# Altium schematic sheet 21_ATK_RK3588S_USB.SchDoc
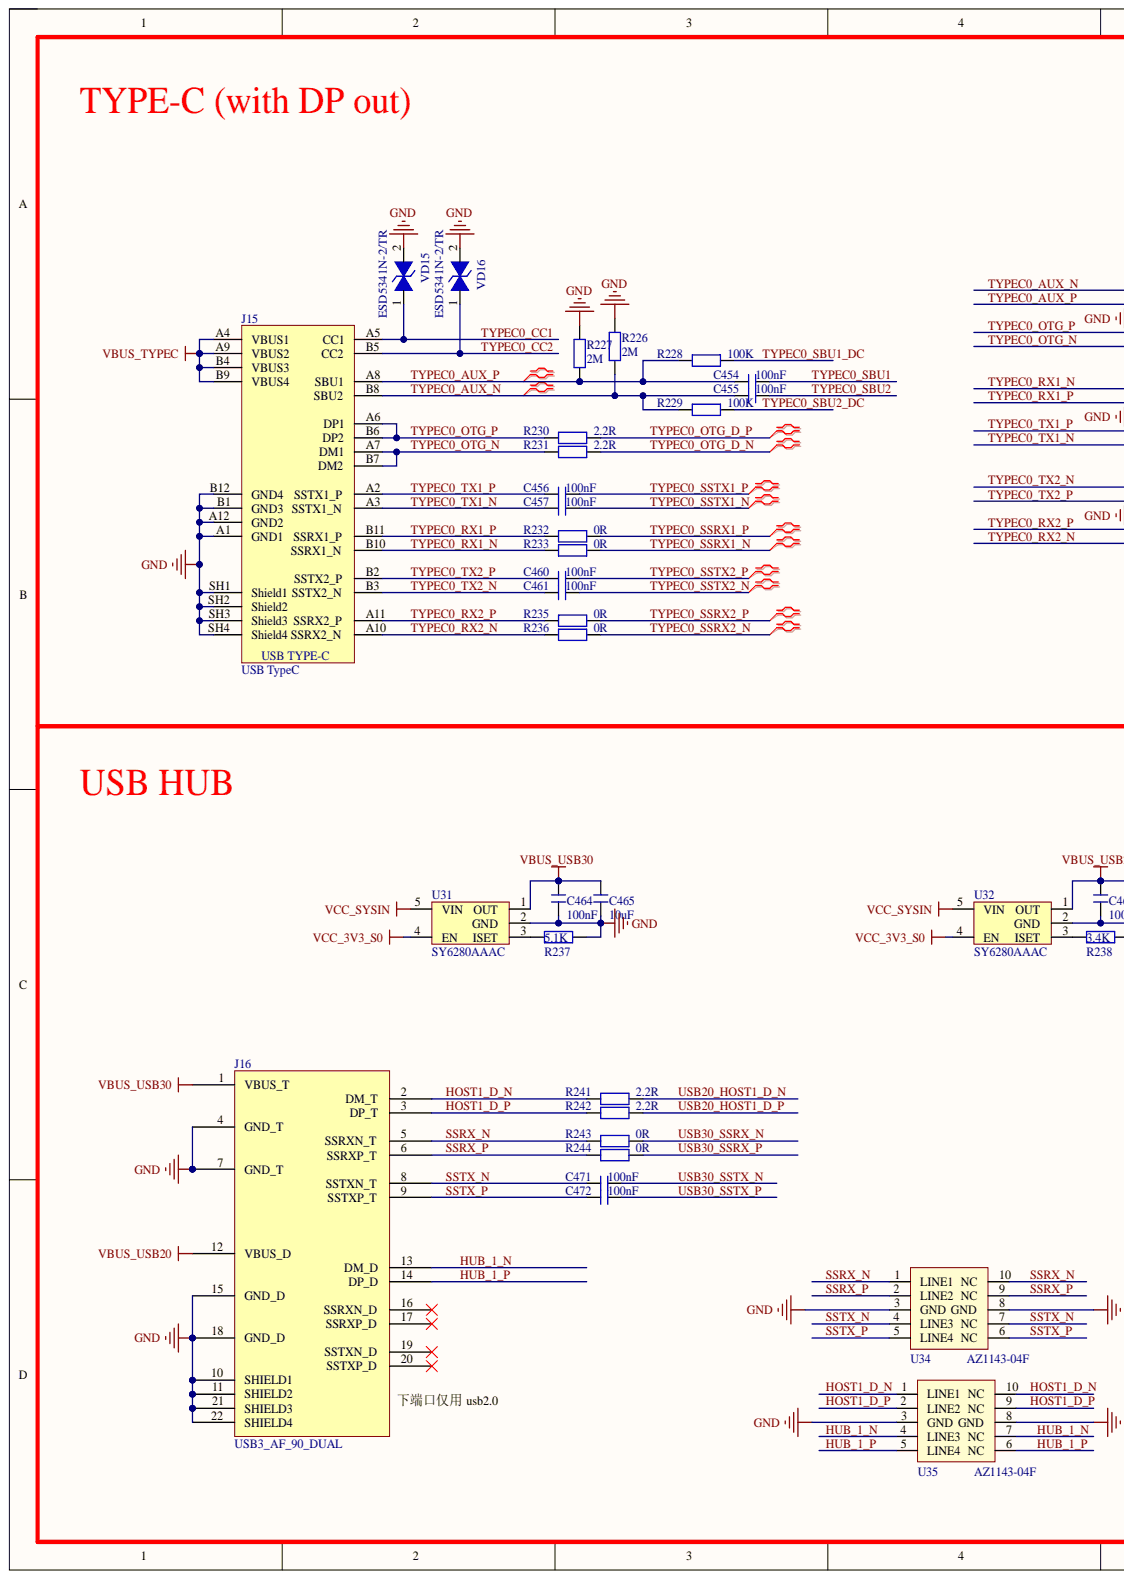
[diagram p0: 21_ATK_RK3588S_USB.SchDoc, left]
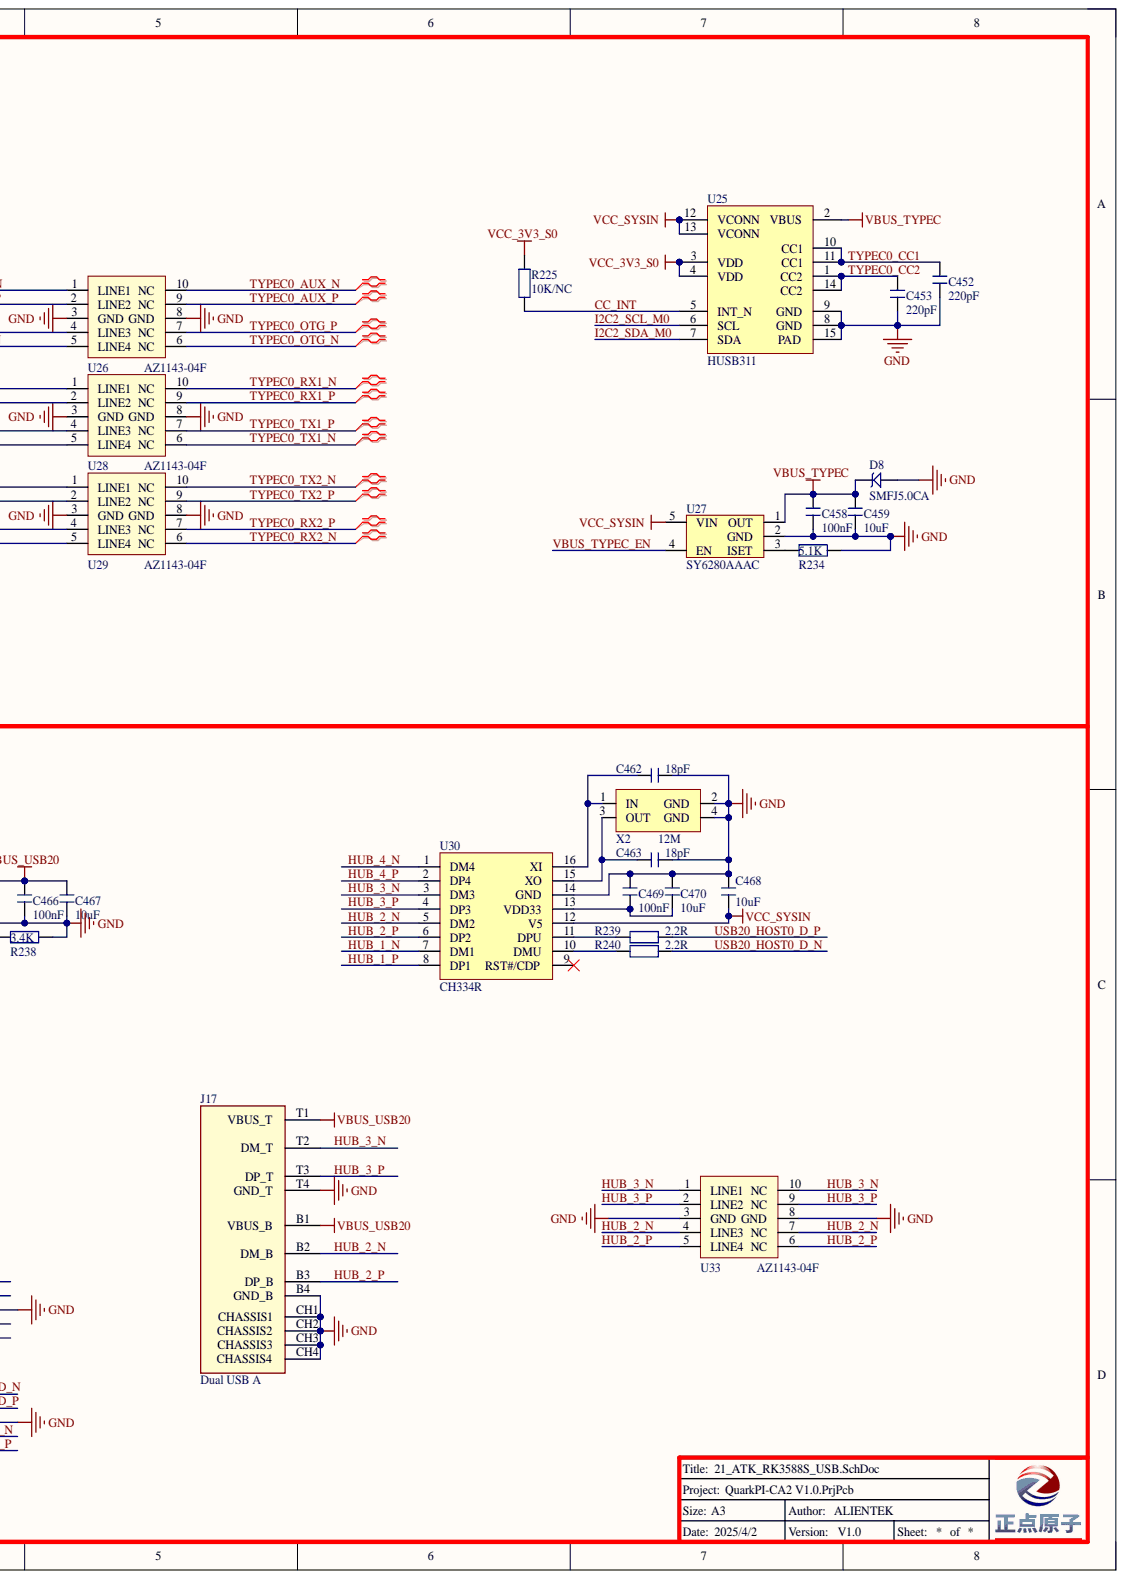
[diagram p1: 21_ATK_RK3588S_USB.SchDoc, right]

## Parts
C452  220pF  [C 0201]
C453  220pF  [C 0201]
C454  100nF  [C 0201]
C455  100nF  [C 0201]
C456  100nF  [C 0201]
C457  100nF  [C 0201]
C458  100nF  [C 0201]
C459  10uF  [C 0402]
C460  100nF  [C 0201]
C461  100nF  [C 0201]
C462  18pF  [C 0402]
C463  18pF  [C 0402]
C464  100nF  [C 0201]
C465  10uF  [C 0402]
C466  100nF  [C 0201]
C467  10uF  [C 0402]
C468  10uF  [C 0402]
C469  100nF  [C 0201]
C470  10uF  [C 0402]
C471  100nF  [C 0201]
C472  100nF  [C 0201]
D8  SMFJ5.0CA  [SOD-123]
J15  USB TypeC  [USB3.2 TYPE-C-24P SMD]
J16  USB3_AF_90_DUAL  [USB3_AF_90_DUAL]
J17  Dual USB A  [USB 2.0 TypeA Dual]
R225  10K/NC  [R 0402]
R226  2M  [R 0402]
R227  2M  [R 0402]
R228  100K  [R 0201]
R229  100K  [R 0201]
R230  2.2R  [R 0201]
R231  2.2R  [R 0201]
R232  0R  [R 0201]
R233  0R  [R 0201]
R234  5.1K  [R 0402]
R235  0R  [R 0201]
R236  0R  [R 0201]
R237  5.1K  [R 0402]
R238  3.4K  [R 0402]
R239  2.2R  [R 0201]
R240  2.2R  [R 0201]
R241  2.2R  [R 0201]
R242  2.2R  [R 0201]
R243  0R  [R 0201]
R244  0R  [R 0201]
U25  HUSB311  [MLP14]
U26  AZ1143-04F  [DFN2510P10E]
U27  SY6280AAAC  [SOT23-5N]
U28  AZ1143-04F  [DFN2510P10E]
U29  AZ1143-04F  [DFN2510P10E]
U30  CH334R  [QSOP16]
U31  SY6280AAAC  [SOT23-5N]
U32  SY6280AAAC  [SOT23-5N]
U33  AZ1143-04F  [DFN2510P10E]
U34  AZ1143-04F  [DFN2510P10E]
U35  AZ1143-04F  [DFN2510P10E]
VD15  ESD5341N-2/TR  [ESD0402]
VD16  ESD5341N-2/TR  [ESD0402]
X2  12M  [XTAL-3225]

## Nets
GND: C452.2 C453.2 C458.2 C459.2 C462.2 C463.2 C464.2 C465.2 C466.2 C467.2 C468.1 C469.1 C470.1 D8.1 J15.A1(GND1) J15.A12(GND2) J15.B1(GND3) J15.B12(GND4) J15.SH1(Shield1) J15.SH2(Shield2) J15.SH3(Shield3) J15.SH4(Shield4) J16.4(GND_T) J16.7(GND_T) J16.10(SHIELD1) J16.11(SHIELD2) J16.15(GND_D) J16.18(GND_D) J16.21(SHIELD3) J16.22(SHIELD4) J17.B4(GND_B) J17.CH1(CHASSIS1) J17.CH2(CHASSIS2) J17.CH3(CHASSIS3) J17.CH4(CHASSIS4) J17.T4(GND_T) R226.2 R227.2 R234.1 R237.1 R238.1 U25.8(GND) U25.9(GND) U25.15(PAD) U26.3(GND) U26.8(GND) U27.2(GND) U28.3(GND) U28.8(GND) U29.3(GND) U29.8(GND) U30.14(GND) U31.2(GND) U32.2(GND) U33.3(GND) U33.8(GND) U34.3(GND) U34.8(GND) U35.3(GND) U35.8(GND) VD15.2 VD16.2 X2.2(GND) X2.4(GND)
VBUS_TYPEC: C458.1 C459.1 D8.2 J15.A4(VBUS1) J15.A9(VBUS2) J15.B4(VBUS3) J15.B9(VBUS4) U25.2(VBUS) U27.1(OUT)
VBUS_USB20: C466.1 C467.1 J16.12(VBUS_D) J17.B1(VBUS_B) J17.T1(VBUS_T) U32.1(OUT)
VBUS_USB30: C464.1 C465.1 J16.1(VBUS_T) U31.1(OUT)
VCC_3V3_S0: R225.1 U25.3(VDD) U25.4(VDD) U31.4(EN) U32.4(EN)
VCC_SYSIN: C468.2 U25.12(VCONN) U25.13(VCONN) U27.5(VIN) U30.12(V5) U31.5(VIN) U32.5(VIN)
CC_INT: R225.2 U25.5(INT_N)
HOST1_D_N: J16.2(DM_T) R241.1 U35.1(LINE1) U35.10(NC)
HOST1_D_P: J16.3(DP_T) R242.1 U35.2(LINE2) U35.9(NC)
HUB_1_N: J16.13(DM_D) U30.7(DM1) U35.4(LINE3) U35.7(NC)
HUB_1_P: J16.14(DP_D) U30.8(DP1) U35.5(LINE4) U35.6(NC)
HUB_2_N: J17.B2(DM_B) U30.5(DM2) U33.4(LINE3) U33.7(NC)
HUB_2_P: J17.B3(DP_B) U30.6(DP2) U33.5(LINE4) U33.6(NC)
HUB_3_N: J17.T2(DM_T) U30.3(DM3) U33.1(LINE1) U33.10(NC)
HUB_3_P: J17.T3(DP_T) U30.4(DP3) U33.2(LINE2) U33.9(NC)
HUB_4_N: U30.1(DM4)
HUB_4_P: U30.2(DP4)
I2C2_SCL_M0: U25.6(SCL)
I2C2_SDA_M0: U25.7(SDA)
SSRX_N: J16.5(SSRXN_T) R243.1 U34.1(LINE1) U34.10(NC)
SSRX_P: J16.6(SSRXP_T) R244.1 U34.2(LINE2) U34.9(NC)
SSTX_N: C471.2 J16.8(SSTXN_T) U34.4(LINE3) U34.7(NC)
SSTX_P: C472.2 J16.9(SSTXP_T) U34.5(LINE4) U34.6(NC)
TYPEC0_AUX_N: C455.2 J15.B8(SBU2) R226.1 R229.1 U26.1(LINE1) U26.10(NC)
TYPEC0_AUX_P: C454.2 J15.A8(SBU1) R227.1 R228.1 U26.2(LINE2) U26.9(NC)
TYPEC0_CC1: C452.1 J15.A5(CC1) U25.10(CC1) U25.11(CC1) VD15.1
TYPEC0_CC2: C453.1 J15.B5(CC2) U25.1(CC2) U25.14(CC2) VD16.1
TYPEC0_OTG_D_N: R231.2
TYPEC0_OTG_D_P: R230.2
TYPEC0_OTG_N: J15.A7(DM1) J15.B7(DM2) R231.1 U26.5(LINE4) U26.6(NC)
TYPEC0_OTG_P: J15.A6(DP1) J15.B6(DP2) R230.1 U26.4(LINE3) U26.7(NC)
TYPEC0_RX1_N: J15.B10(SSRX1_N) R233.1 U28.1(LINE1) U28.10(NC)
TYPEC0_RX1_P: J15.B11(SSRX1_P) R232.1 U28.2(LINE2) U28.9(NC)
TYPEC0_RX2_N: J15.A10(SSRX2_N) R236.1 U29.5(LINE4) U29.6(NC)
TYPEC0_RX2_P: J15.A11(SSRX2_P) R235.1 U29.4(LINE3) U29.7(NC)
TYPEC0_SBU1: C454.1
TYPEC0_SBU1_DC: R228.2
TYPEC0_SBU2: C455.1
TYPEC0_SBU2_DC: R229.2
TYPEC0_SSRX1_N: R233.2
TYPEC0_SSRX1_P: R232.2
TYPEC0_SSRX2_N: R236.2
TYPEC0_SSRX2_P: R235.2
TYPEC0_SSTX1_N: C457.1
TYPEC0_SSTX1_P: C456.1
TYPEC0_SSTX2_N: C461.1
TYPEC0_SSTX2_P: C460.1
TYPEC0_TX1_N: C457.2 J15.A3(SSTX1_N) U28.5(LINE4) U28.6(NC)
TYPEC0_TX1_P: C456.2 J15.A2(SSTX1_P) U28.4(LINE3) U28.7(NC)
TYPEC0_TX2_N: C461.2 J15.B3(SSTX2_N) U29.1(LINE1) U29.10(NC)
TYPEC0_TX2_P: C460.2 J15.B2(SSTX2_P) U29.2(LINE2) U29.9(NC)
USB20_HOST0_D_N: R240.2
USB20_HOST0_D_P: R239.2
USB20_HOST1_D_N: R241.2
USB20_HOST1_D_P: R242.2
USB30_SSRX_N: R243.2
USB30_SSRX_P: R244.2
USB30_SSTX_N: C471.1
USB30_SSTX_P: C472.1
VBUS_TYPEC_EN: U27.4(EN)
NetC462_1: C462.1 U30.16(XI) X2.1(IN)
NetC463_1: C463.1 U30.15(XO) X2.3(OUT)
NetC469_2: C469.2 C470.2 U30.13(VDD33)
NetR234_2: R234.2 U27.3(ISET)
NetR237_2: R237.2 U31.3(ISET)
NetR238_2: R238.2 U32.3(ISET)
NetR239_1: R239.1 U30.11(DPU)
NetR240_1: R240.1 U30.10(DMU)

Not connected: J16 16(SSRXN_D) 17(SSRXP_D) 19(SSTXN_D) 20(SSTXP_D); U30 9(RST#/CDP)

## Library data of the parts
C452-C472 = C: 无极性电容; BOM名称: 无极性贴片电容; 版权归属: 正点原子; Component Kind: Standard; Library Name: 正点原子封装库V1.0.IntLib; 学习资源: www.openedv.com
D8 = SMBJ: TVS; Component Kind: Standard; Library Name: AtomPi-CA1 V1.1.IntLib
J17 = USB 2.0 TypeA Dual: 双层USB2.0 Type A; Parameter: 接口器件; 版权归属: 正点原子; Component Kind: Standard; Library Name: 正点原子封装库V1.0.IntLib; 学习资源: www.openedv.com
R225-R244 = R: 电阻; BOM名称: 贴片电阻; 版权归属: 正点原子; Component Kind: Standard; Library Name: 正点原子封装库V1.0.IntLib; Parameter: 电阻; 学习资源: www.openedv.com
U25 = FUSB302MPX: USB Type-C 控制器; Component Kind: Standard; Library Name: ATK-DLRK3588B V0.2.IntLib
U26 U28 U29 U33-U35 = AZ1143-04F: ESD保护二极管阵列; Component Kind: Standard; Library Name: ATK-DLRK3588B V0.2.IntLib
U27 U31 U32 = MT9700HT5: 功率开关(5.5V-2A); BOM名称: 稳压芯片; Parameter: 芯片; 版权归属: 正点原子; Component Kind: Standard; Library Name: 正点原子封装库V1.0.IntLib; 学习资源: www.openedv.com
U30 = CH334R: 4端口USB HUB控制器, USB2.0; Component Kind: Standard; Library Name: ATK-DLIMX93.IntLib
VD15 VD16 = ESD5341N: ESD二极管-单向; Component Kind: Standard; Library Name: ATK-DLRK3588B V0.2.IntLib
X2 = XTAL-4P: 4脚无源晶振; BOM名称: 4脚晶振; Parameter: 晶振; 版权归属: 正点原子; Component Kind: Standard; Library Name: 正点原子封装库V1.0.IntLib; 学习资源: www.openedv.com
J15 = USB TypeC: Component Kind: Standard; Library Name: ATK-DLRK3588B V0.2.IntLib
J16 = USB3_AF_90_DUAL: Component Kind: Standard; Library Name: atompi-ca2.SchLib
